# Altium schematic sheet POWER_SUPPLY.SchDoc(POWER_SUPPLY)
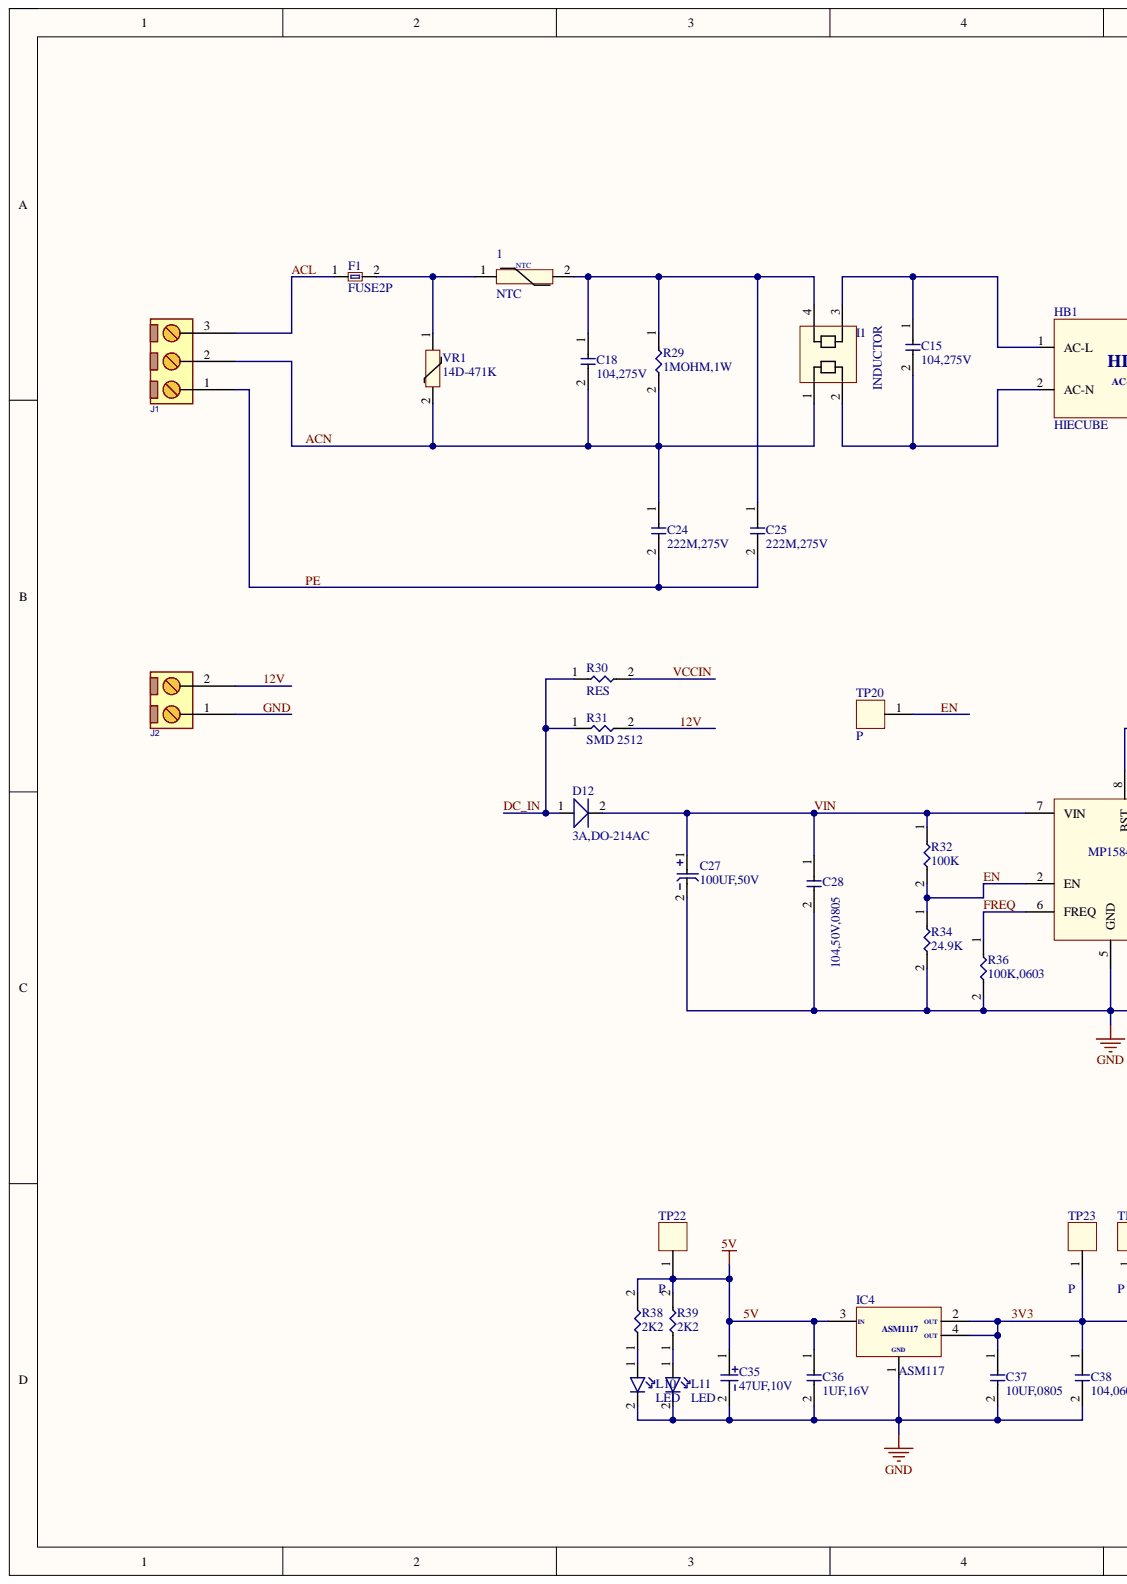
[diagram p0: POWER_SUPPLY.SchDoc, left]
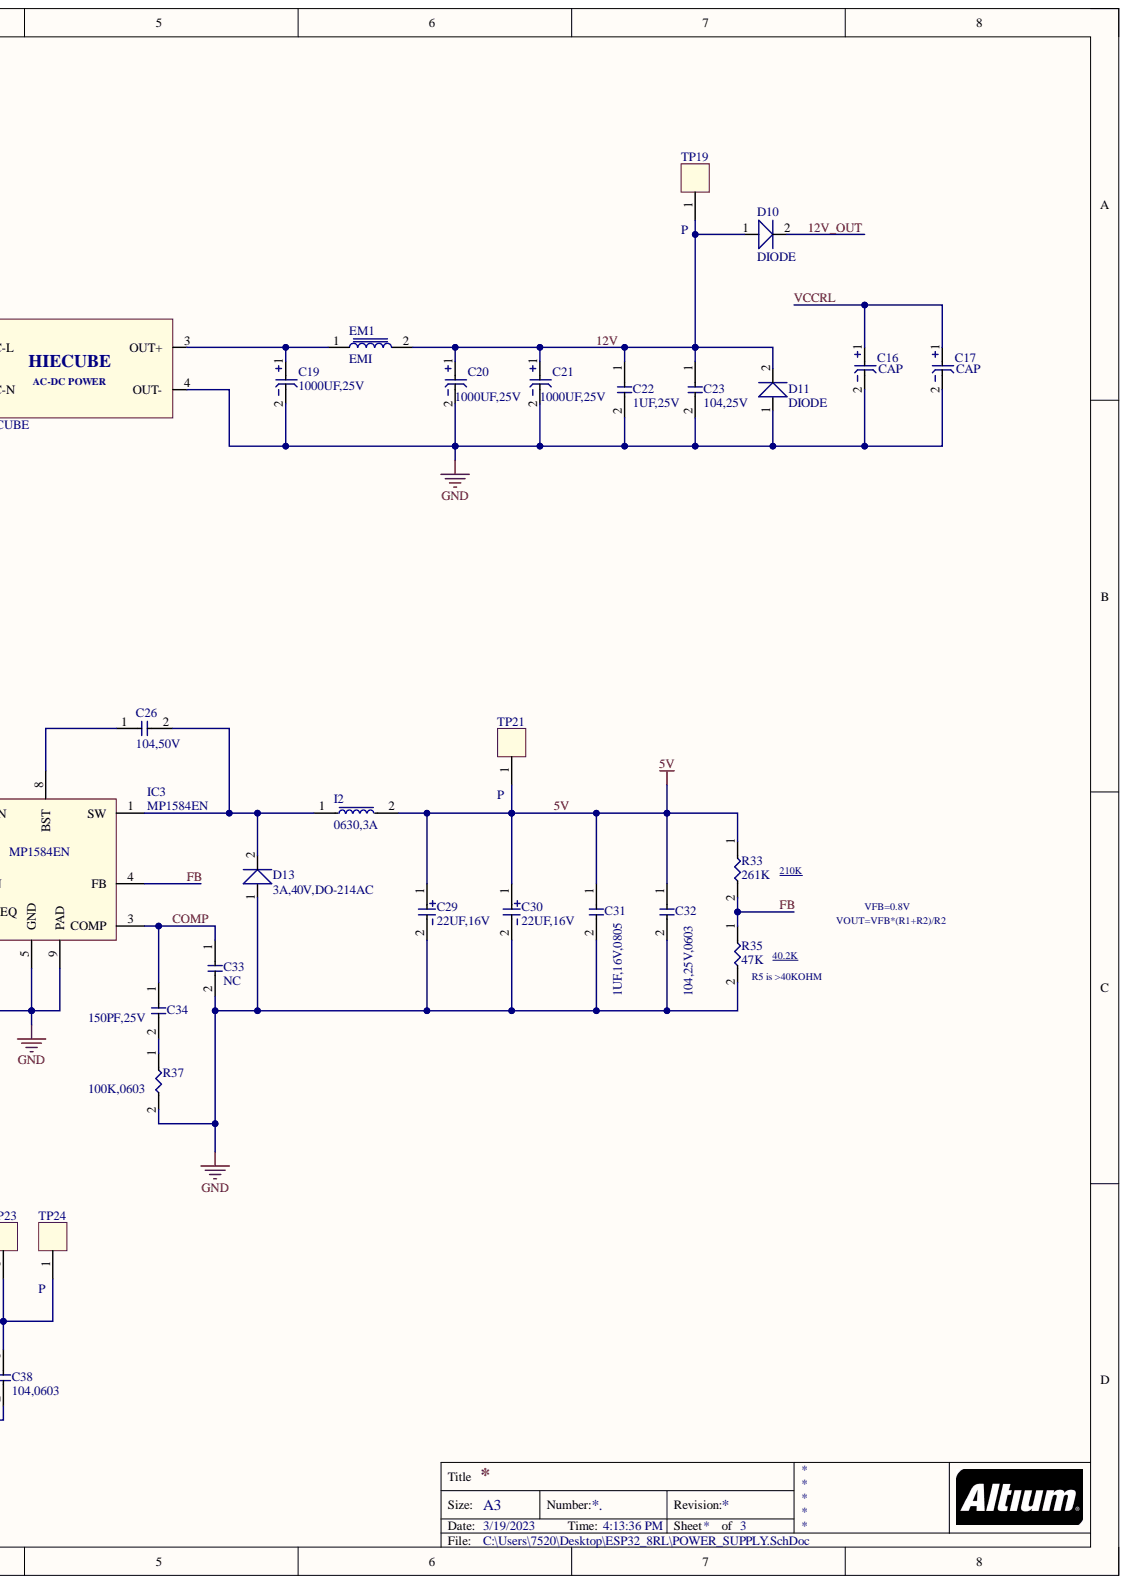
[diagram p1: POWER_SUPPLY.SchDoc, right]

## Parts
1  NTC  [NTC10D11]
C15  104,275V  [CAP_GOLD_DIP]
C16  CAP  [CAP_POL_DIP]
C17  CAP  [CAP_POL_DIP]
C18  104,275V  [CAP_GOLD_DIP]
C19  1000UF,25V  [CAP_POL_DIP]
C20  1000UF,25V  [CAP_POL_DIP]
C21  1000UF,25V  [CAP_POL_DIP]
C22  1UF,25V  [cap_smd_0805]
C23  104,25V  [cap_smd_0603]
C24  222M,275V  [CAP_CER_AC]
C25  222M,275V  [CAP_CER_AC]
C26  104,50V  [cap_smd_0603]
C27  100UF,50V  [CAP_ALU_D8_63MM]
C28  104,50V,0805  [cap_smd_0805]
C29  22UF,16V  [CAP_TAN_B]
C30  22UF,16V  [CAP_TAN_B]
C31  1UF,16V,0805  [cap_smd_0805]
C32  104,25V,0603  [cap_smd_0603]
C33  NC  [cap_smd_0603]
C34  150PF,25V  [cap_smd_0603]
C35  47UF,10V  [CAP_TAN_B]
C36  1UF,16V  [cap_smd_0805]
C37  10UF,0805  [cap_smd_0805]
C38  104,0603  [cap_smd_0603]
D10  DIODE  [diode_smd_DO_214AC]
D11  DIODE  [diode_smd_DO_214AC]
D12  3A,DO-214AC  [diode_smd_DO_214AC]
D13  3A,40V,DO-214AC  [diode_smd_DO_214AC]
EM1  EMI  [EMI_FILTER]
F1  FUSE2P  [FAC2A]
HB1  HIECUBE  [HIECUBE12VDC]
I1  INDUCTOR  [IN_FILTER]
I2  0630,3A  [0630]
IC3  MP1584EN  [MP1584EN-LF-Z]
IC4  ASM117  [ASM1117]
J1  CONN_TB3  [P_TBG_3]  (value not drawn)
J2  CONN_TB2  [P_TBG_2]  (value not drawn)
L10  LED  [LED_3MM_DIP]
L11  LED  [LED_0603_RED]
R29  1MOHM,1W  [RES_DIP]
R30  RES  [0805_R_L]
R31  SMD 2512  [2512_R_L]
R32  100K  [0603_R_L]
R33  261K  [0603_R_L]
R34  24.9K  [0603_R_L]
R35  47K  [0603_R_L]
R36  100K,0603  [0603_R_L]
R37  100K,0603  [0603_R_L]
R38  2K2  [0603_R_L]
R39  2K2  [0603_R_L]
TP19  P  [TP]
TP20  P  [TP]
TP21  P  [TP]
TP22  P  [TP]
TP23  P  [TP]
TP24  P  [TP]
VR1  14D-471K  [VARISTOR]

## Nets
3V3: C37.1 C38.1 IC4.2(OUT) IC4.4(OUT) TP23.1 TP24.1
5V: C29.1 C30.1 C31.1 C32.1 C35.1 C36.1 I2.2 IC4.3(IN) R33.1 R38.2 R39.2 TP21.1 TP22.1
12V: C20.1 C21.1 C22.1 C23.1 D10.1 D11.2 EM1.2 J2.2 R31.2 TP19.1
12V_OUT: D10.2
ACL: F1.1 J1.3
ACN: C18.2 C24.1 I1.1 J1.2 R29.2 VR1.2
COMP: C33.1 C34.1 IC3.3(COMP)
DC_IN: D12.1 R30.1 R31.1
EN: IC3.2(EN) R32.2 R34.1 TP20.1
FB: IC3.4(FB) R33.2 R35.1
FREQ: IC3.6(FREQ) R36.1
GND: C16.2 C17.2 C19.2 C20.2 C21.2 C22.2 C23.2 C27.2 C28.2 C29.2 C30.2 C31.2 C32.2 C33.2 C35.2 C36.2 C37.2 C38.2 D11.1 D13.1 HB1.4(OUT-) IC3.5(GND) IC3.9(PAD) IC4.1 J2.1 L10.2 L11.2 R34.2 R35.2 R36.2 R37.2
PE: C24.2 C25.2 J1.1
VCCIN: R30.2
VCCRL: C16.1 C17.1
VIN: C27.1 C28.1 D12.2 IC3.7(VIN) R32.1
Net1_1: 1.1 F1.2 VR1.1
Net1_2: 1.2 C18.1 C25.1 I1.4 R29.1
NetC15_1: C15.1 HB1.1(AC-L) I1.3
NetC15_2: C15.2 HB1.2(AC-N) I1.2
NetC19_1: C19.1 EM1.1 HB1.3(OUT+)
NetC26_1: C26.1 IC3.8(BST)
NetC26_2: C26.2 D13.2 I2.1 IC3.1(SW)
NetC34_2: C34.2 R37.1
NetL10_1: L10.1 R38.1
NetL11_1: L11.1 R39.1

## Library data of the parts
C15 C18 C22-C26 C28 C31-C34 C36-C38 = CAPACITOR: Component Kind: Standard; Library Name: COMPONENT.IntLib
C16 C17 C19-C21 C27 = CAP_ALU: Component Kind: Standard; Library Name: COMPONENT.IntLib
C29 C30 C35 = CAP_TAN: Component Kind: Standard; Library Name: COMPONENT.IntLib
D12 D13 = DIODE: Component Kind: Standard; Library Name: COMPONENT.IntLib
EM1 = EMI_FILTER: Component Kind: Standard; Library Name: COMPONENT.IntLib
F1 = FUSE: Component Kind: Standard; Library Name: COMPONENT.IntLib
HB1 = HB_12VDC: Component Kind: Standard; Library Name: nguon_xung.IntLib
I1 = IN_FILTER: Component Kind: Standard; Library Name: COMPONENT.IntLib
I2 = INDUCTOR: INDUCTOR ,CD47,100,150UH,SIZE 12X12X7; Component Kind: Standard; Library Name: COMPONENT.IntLib
IC3 = MP1584EN-LF-Z: Component Kind: Standard; Library Name: POWER_SUPPLY_ICs.IntLib
IC4 = ASM1117: Component Kind: Standard; Library Name: POWER_SUPPLY_ICs.IntLib
J1 = TB3 GREEN: CONN, TERMINAL BLOCK, 5.08mm pitch; Component Kind: Standard; Library Name: TERMINAL.IntLib; VALUE: CONN_TB3
J2 = TB2 GREEN: CONN, TERMINAL BLOCK, 5.08mm pitch; Component Kind: Standard; Library Name: TERMINAL.IntLib; VALUE: CONN_TB2
R29 R31-R39 = RESISTOR: Component Kind: Standard; Description: resistor; Library Name: COMPONENT.IntLib
R30 = RESISTOR: TYPE DIP & SMD; Component Kind: Standard; Library Name: COMPONENT.IntLib
TP19-TP24 = TP: Component Kind: Standard; Library Name: COMPONENT.IntLib
VR1 = MOV: Component Kind: Standard; Library Name: COMPONENT.IntLib
1 = NTC: Component Kind: Standard; Library Name: COMPONENT.IntLib
D10 D11 = DIODE: Component Kind: Standard; Library Name: COMPONENT.IntLib
L10 L11 = LED: Component Kind: Standard; Library Name: COMPONENT.IntLib
